# Altium schematic sheet TSAL_Control.SchDoc
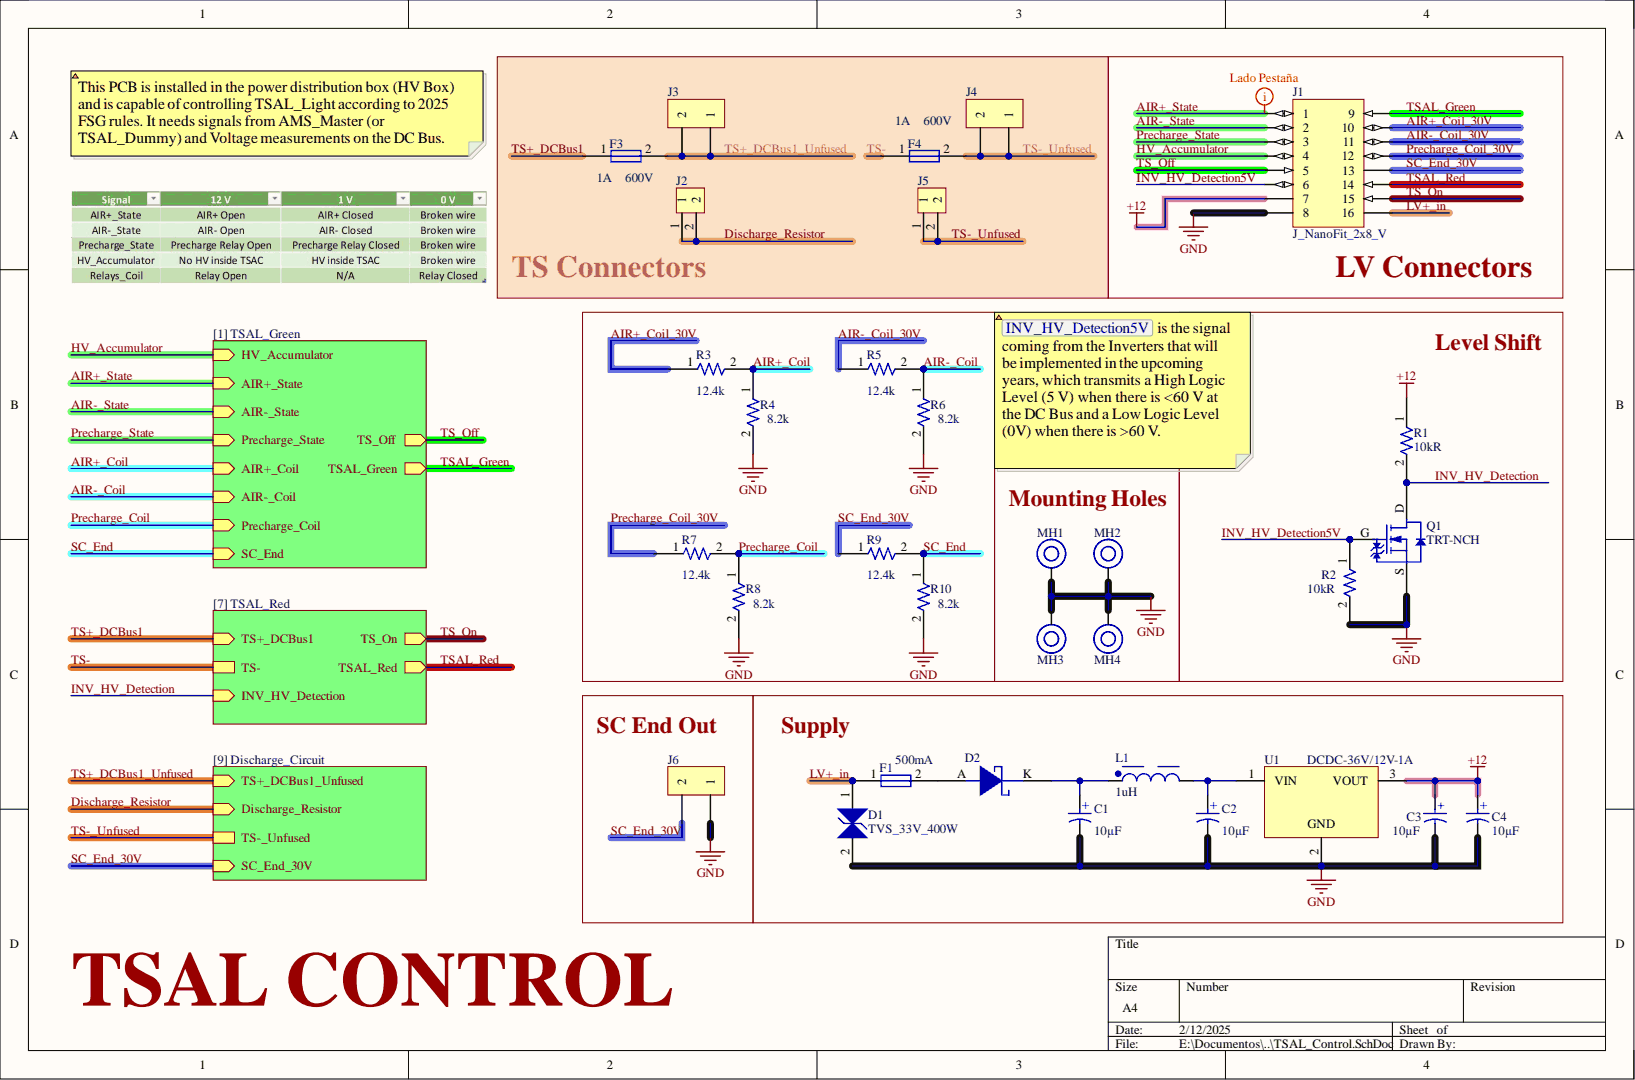
[diagram p0: TSAL_Control.SchDoc]

## Parts
C1  value 10µF  [865080540004-Footprint-1]  (hidden comment: C10u-35V-Electrolytic)
C2  value 10µF  [865080540004-Footprint-1]  (hidden comment: C10u-35V-Electrolytic)
C3  value 10µF  [865080540004-Footprint-1]  (hidden comment: C10u-35V-Electrolytic)
C4  value 10µF  [865080540004-Footprint-1]  (hidden comment: C10u-35V-Electrolytic)
D1  TVS_33V_400W  [WE-TVSP_DO-214AC_Bidirectional]
D2  Average Rectified Current: 500mA  [DSC-SOD123]  (hidden comment: DSC-0.5/30-SOD123)
F1  Current Rating: 500mA  [0805]  (hidden comment: MFU0805FF00500P500)
F3  Current Rating: 1A  Voltage Rating: 600V  [Fuse_485001]  (hidden comment: Fuse_1A_600V)
F4  Current Rating: 1A  Voltage Rating: 600V  [Fuse_485001]  (hidden comment: Fuse_1A_600V)
J1  J_NanoFit_2x8_V  [J_NanoFit_2x8_V]
J2  J_MicroFit_2x1  [J_MicroFit_2x1]  (value not drawn)
J3  J_NanoFit_1x2  [FP-105309-1102-MFG]  (value not drawn)
J4  J_NanoFit_1x2  [FP-105309-1102-MFG]  (value not drawn)
J5  J_MicroFit_2x1  [J_MicroFit_2x1]  (value not drawn)
J6  J_NanoFit_1x2  [FP-105309-1102-MFG]  (value not drawn)
L1  value 1uH  [WE-PD2_4532]  (hidden comment: 7447730)
MH1  Mounting_Hole_M3  [Mounting_Hole_M3]  (value not drawn)
MH2  Mounting_Hole_M3  [Mounting_Hole_M3]  (value not drawn)
MH3  Mounting_Hole_M3  [Mounting_Hole_M3]  (value not drawn)
MH4  Mounting_Hole_M3  [Mounting_Hole_M3]  (value not drawn)
Q1  TRT-NCH  [TRT-NCH-3/35-CPH]
R1  10kR  [R0805FTK]
R2  value 10kR  [R0805FTK]  (hidden comment: R10k-0805FTK)
R3  value 12.4k  [0805]  (hidden comment: R12K4-0805-0.125W)
R4  value 8.2k  [0805]  (hidden comment: R8.2K_0805_0.125W)
R5  value 12.4k  [0805]  (hidden comment: R12K4-0805-0.125W)
R6  value 8.2k  [0805]  (hidden comment: R8.2K_0805_0.125W)
R7  value 12.4k  [0805]  (hidden comment: R12K4-0805-0.125W)
R8  value 8.2k  [0805]  (hidden comment: R8.2K_0805_0.125W)
R9  value 12.4k  [0805]  (hidden comment: R12K4-0805-0.125W)
R10  value 8.2k  [0805]  (hidden comment: R8.2K_0805_0.125W)
U1  DCDC-36V/12V-1A  [WPME-FDSM_SIP-3_L11.6W8H10.4]

## Nets
+12: C3.1 C4.1 J1.7 R1.1 U1.3
GND: C1.2 C2.2 C3.2 C4.2 D1.2 J1.8 J6.1 MH1.1 MH2.1 MH3.1 MH4.1 Q1.S R2.2 R4.2 R6.2 R8.2 R10.2 U1.2
AIR+_Coil: R3.2 R4.1
AIR+_Coil_30V: J1.10 R3.1
AIR+_State: J1.1
AIR-_Coil: R5.2 R6.1
AIR-_Coil_30V: J1.11 R5.1
AIR-_State: J1.2
Discharge_Resistor: J2.1 J2.2
HV_Accumulator: J1.4
INV_HV_Detection: Q1.D R1.2
INV_HV_Detection5V: J1.6 Q1.G R2.1
LV+_in: D1.1 F1.1 J1.16
Precharge_Coil: R7.2 R8.1
Precharge_Coil_30V: J1.12 R7.1
Precharge_State: J1.3
SC_End: R9.2 R10.1
SC_End_30V: J1.13 J6.2 R9.1
TS+_DCBus1: F3.1
TS+_DCBus1_Unfused: F3.2 J3.1 J3.2
TS-: F4.1
TS-_Unfused: F4.2 J4.1 J4.2 J5.1 J5.2
TS_Off: J1.5
TS_On: J1.15
TSAL_Green: J1.9
TSAL_Red: J1.14
NetC1_1: C1.1 D2.K L1.1
NetC2_1: C2.1 L1.2 U1.1
NetD2_A: D2.A F1.2

## Library data of the parts
C1-C4 = CMP-001-00019-3: WCAP-ASLI Aluminum Electrolytic Capacitors; Ripple Current: 90mA; Tolerance: 20%; Voltage Rating: 35V; Case/Package: Radial; Component Kind: Standard; Max Operating Temperature: 105°C; Min Operating Temperature: -55°C; Mounting Technology: Surface Mount; Ripple Current (AC): 90mA; RoHS Compliant: Yes; Library Name: EMEA- E-Tech Racing- I'Escola d'Enginyeria de Barcelona Est
D1 = CMP-004-00003-1: Trans Voltage Suppressor Diode, 400W, Bidirectional, 1 Element, Silicon, DO-214AC; Component Kind: Standard; Max Operating Temperature: 150°C; Min Operating Temperature: -65°C; Pins: 2; RoHS Compliant: Yes; Library Name: EMEA- E-Tech Racing- I'Escola d'Enginyeria de Barcelona Est
D2 = CMP-00165-2: VR=30V IF=500mA 30pF P=410mW; .Creator: GP; Element Configuration: Single; Forward Voltage: 430mV; Max Forward Surge Current (Ifsm): 5.5A; Max Repetitive Reverse Voltage (Vrrm): 30V; Max Reverse Current: 130uA; Polarity: Standard; Category: Diode; Component Kind: Standard; ComponentLink1Description: Datasheet; ComponentLink2Description: Where buy it; ComponentLink3Description: https://datasheet.ciiva.com/30479/0900766b810e2512-30479401.pdf?src-supplier=RSComponents; ComponentLink3URL: https://datasheet.ciiva.com/30479/0900766b810e2512-30479401.pdf?src-supplier=RSComponents; ComponentLink4Description: https://datasheet.ciiva.com/34301/974753-34301826.pdf?src-supplier=Jameco; ComponentLink4URL: https://datasheet.ciiva.com/34301/974753-34301826.pdf?src-supplier=Jameco; ComponentLink5Description: https://datasheet.ciiva.com/910/mbr0530-910516.pdf?src-supplier=Digi-Key; ComponentLink5URL: https://datasheet.ciiva.com/910/mbr0530-910516.pdf?src-supplier=Digi-Key; ComponentLink6Description: https://datasheet.ciiva.com/25931/mbr0530-25931989.pdf?src-supplier=Avnet+Europe; ComponentLink6URL: https://datasheet.ciiva.com/25931/mbr0530-25931989.pdf?src-supplier=Avnet+Europe; ComponentLink7Description: https://datasheet.ciiva.com/8300/mbr0530-8300905.pdf?src-supplier=Avnet; ComponentLink7URL: https://datasheet.ciiva.com/8300/mbr0530-8300905.pdf?src-supplier=Avnet; ComponentLink8Description: https://datasheet.ciiva.com/17318/mbr0530-17318568.pdf?src-supplier=Future+Electronics; ComponentLink8URL: https://datasheet.ciiva.com/17318/mbr0530-17318568.pdf?src-supplier=Future+Electronics; ComponentLink9Description: https://datasheet.ciiva.com/15144/getdatasheetpartid-86760-15144598.pdf?src-supplier=Verical; ComponentLink9URL: https://datasheet.ciiva.com/15144/getdatasheetpartid-86760-15144598.pdf?src-supplier=Verical; ComponentLink10Description: https://datasheet.ciiva.com/910/mbr0530-910518.pdf?src-supplier=Digi-Key; ComponentLink10URL: https://datasheet.ciiva.com/910/mbr0530-910518.pdf?src-supplier=Digi-Key; Contact Plating: Tin,Matte; Current Rating: 500mA; Design Item ID: DSC-0.5/30-SOD123; Forward Current: 500mA; Height: 1.03mm; Lead Free: Lead Free; Length: 2.7mm; Max Reverse Leakage Current: 130uA; Max Reverse Voltage (DC): 30V; Max Surge Current: 5.5A; Mount: Surface Mount; Output Current: 500mA; Package Quantity: 1; Peak Non-Repetitive Surge Current: 5.5A; Pricing 1: 1=0,34400, 10=0,23900, 100=0,12200, 500=0,10000 (USD); Radiation Hardening: No; REACH SVHC: No SVHC; RoHS Compliant: Yes; Series: -; Source: Filter components.SchLib; Stock 1: 9; Voltage Rating (DC): 30V; Weight: 0.03g; Width: 1.6mm; Library Name: EMEA- E-Tech Racing- I'Escola d'Enginyeria de Barcelona Est
F1 = CMP-001-00020-3: Fuse, 24 Milliohms to 660 Milliohms (Cold) @ 0.1, 32 V, 0805, 15 C, 35 C; Value: 0.5A-50V; Case/Package: 0805; Component Kind: Standard; Max Operating Temperature: 125°C; Min Operating Temperature: 15°C; Mounting Technology: Surface Mount,PCB; Pins: 2; RoHS Compliant: Yes; Library Name: EMEA- E-Tech Racing- I'Escola d'Enginyeria de Barcelona Est
F3 F4 = CMP-00161-2: Surface Mount Fuses 600V 1A Fast Acting; Manufacturer 1: Littelfuse; Manufacturer Part Number 1: 0485001.DR; .Creator: DO; Approvals: UL,CSA; Body Material: Ceramic; DC Cold Resistance: 304.4mR; Fuse Type: Board Mount (Cartridge Style Excluded); Resistance: 0.264; Voltage Rating (AC): 250V; Case/Package: SMD/SMT; Category: Fuses; Component Kind: Standard; ComponentLink1Description: http://www.farnell.com/datasheets/1945989.pdf?src-supplier=Farnell; ComponentLink1URL: http://www.farnell.com/datasheets/1945989.pdf?src-supplier=Farnell; ComponentLink2Description: http://www.farnell.com/datasheets/2282568.pdf?src-supplier=Farnell; ComponentLink2URL: http://www.farnell.com/datasheets/2282568.pdf?src-supplier=Farnell; ComponentLink3Description: http://www.mouser.com/ds/2/240/Littelfuse485_datasheet_080512-201879.pdf?src-supplier=Mouser; ComponentLink3URL: http://www.mouser.com/ds/2/240/Littelfuse485_datasheet_080512-201879.pdf?src-supplier=Mouser; ComponentLink4Description: http://components.arrow.com/part/dataview/56707787S790276N3675?src-supplier=Arrow+Electronics; ComponentLink4URL: http://components.arrow.com/part/dataview/56707787S790276N3675?src-supplier=Arrow+Electronics; ComponentLink5Description: http://www.littelfuse.com/~/media/Files/Littelfuse/Technical%20Resources/Documents/Data%20Sheets/Littelfuse_Fuse_485_Datasheet.pdf?src-supplier=Element14; ComponentLink5URL: http://www.littelfuse.com/~/media/Files/Littelfuse/Technical%20Resources/Documents/Data%20Sheets/Littelfuse_Fuse_485_Datasheet.pdf?src-supplier=Element14; ComponentLink6Description: http://www.littelfuse.com/~/media/electronics/datasheets/fuses/littelfuse_fuse_485_datasheet.pdf.pdf?src-supplier=Digi-Key; ComponentLink6URL: http://www.littelfuse.com/~/media/electronics/datasheets/fuses/littelfuse_fuse_485_datasheet.pdf.pdf?src-supplier=Digi-Key; Depth: 4.5mm; Design Item ID: Fuse_1A_600V; Fuse Current: 1A; Height: 0.177inch; Housing Material: Ceramic; Lead Free: Lead Free; Length: 12.1mm; Max Operating Temperature: 125°C; Min Operating Temperature: -55°C; Mount: Surface Mount; Package Quantity: 1; Packaging: Tape and Reel; Pricing 1: 1=2,82900, 5=2,81400, 10=2,75200, 50=2,59800, 100=2,40300, 250=2,37900, 500=2,30400, 1500=2,24900, 3000=2,11600 (USD); REACH SVHC: No SVHC; RoHS: Yes; RoHS Compliant: Yes; Series: 485; Source: Filter components.SchLib; Stock 1: 4123; Termination: SMD/SMT; Voltage Rating (DC): 600V; Width: 0.177inch; Library Name: EMEA- E-Tech Racing- I'Escola d'Enginyeria de Barcelona Est
J1 = CMP-00020-3: Nano-Fit Vertical Header Through Hole 2.50mm Pitch Dual Row 16 Circuits with Kinked Pins Tin (Sn) Plating Black; Manufacturer 1: Molex; Manufacturer Part Number 1: 105310-1116; .Creator: AC; Connector Type: Header,Male Pin; Number of Positions: 16; Category: Headers and Wire Housings; Component Kind: Standard; ComponentLink1Description: https://www.molex.com/pdm_docs/sd/1053101210_sd.pdf?src-supplier=Digi-Key; ComponentLink1URL: https://www.molex.com/pdm_docs/sd/1053101210_sd.pdf?src-supplier=Digi-Key; ComponentLink2Description: Molex; ComponentLink2URL: https://www.molex.com/molex/products/part-detail/pcb_headers/1053101116; Contact Material: Brass; Contact Plating: Tin; Contact Shape: Square; Design Item ID: J_NanoFit_2x8_V; Fastening Type: Latch; Insulation Color: Black; Insulation Material: Polymer; Max Operating Temperature: 105°C; Min Operating Temperature: -40°C; Mount: Through Hole; Number of Rows: 2; Packaging: Tray; Pricing 1: 1=3,21000, 10=2,82400, 84=2,24619, 1008=1,86110, 4956=1,66858 (USD); RoHS: No; Source: Electromechanical.SchLib; Stock 1: 84; Termination: Kinked Pin; Library Name: EMEA- E-Tech Racing- I'Escola d'Enginyeria de Barcelona Est
J2 J5 = CMP-00012-1: Header 1 Row Vertical Polarizing Peg 2 Pin SMT/Glow Wire Compatible Tin Plate; Manufacturer 1: Molex; Manufacturer Part Number 1: 43650-0215; Category: Headers and Wire Housings; Component Kind: Standard; ComponentLink1Description: https://datasheet.ciiva.com/19462/884109-19462034.pdf?src-supplier=Jameco; ComponentLink1URL: https://datasheet.ciiva.com/19462/884109-19462034.pdf?src-supplier=Jameco; ComponentLink2Description: https://datasheet.ciiva.com/5157/0436500215-pcb-headers-148687-5157608.pdf?src-supplier=Mouser; ComponentLink2URL: https://datasheet.ciiva.com/5157/0436500215-pcb-headers-148687-5157608.pdf?src-supplier=Mouser; ComponentLink3Description: https://datasheet.ciiva.com/16085/0436500215-pcb-headers-16085668.pdf?src-supplier=Onlinecomponents.com; ComponentLink3URL: https://datasheet.ciiva.com/16085/0436500215-pcb-headers-16085668.pdf?src-supplier=Onlinecomponents.com; ComponentLink4Description: https://datasheet.ciiva.com/11748/0900766b80307ce2-11748388.pdf?src-supplier=RSComponents; ComponentLink4URL: https://datasheet.ciiva.com/11748/0900766b80307ce2-11748388.pdf?src-supplier=RSComponents; ComponentLink5Description: https://datasheet.ciiva.com/9432/0436500215-pcb-headers-9432850.pdf?src-supplier=Avnet; ComponentLink5URL: https://datasheet.ciiva.com/9432/0436500215-pcb-headers-9432850.pdf?src-supplier=Avnet; ComponentLink6Description: https://datasheet.ciiva.com/7920/1889390-7920892.pdf?src-supplier=Element14; ComponentLink6URL: https://datasheet.ciiva.com/7920/1889390-7920892.pdf?src-supplier=Element14; Design Item ID: J_MicroFit_2x1; Pricing 1: 1=0,87800, 10=0,74400, 80=0,63070, 3264=0,47000, 6528=0,45100, 13056=0,41760 (USD); RoHS: Yes; Source: Electromechanical.SchLib; Stock 1: 11086; Library Name: EMEA- E-Tech Racing- I'Escola d'Enginyeria de Barcelona Est
J3 J4 J6 = CMP-002-00001-2: Conn HDR 2 POS 2.5mm Solder ST Thru-Hole 2 Terminal 1 Port Nano-Fit Tray; Component Kind: Standard; Library Name: EMEA- E-Tech Racing- I'Escola d'Enginyeria de Barcelona Est
L1 = CMP-006-00005-1: General Purpose Inductor, 1uH, 20%, 1 Element, Nickel-zinc Ferrite-Core, SMD, 1816; Max Current Rating: 4A; Self Resonant Frequency: 110MHz; Component Kind: Standard; Max Operating Temperature: 125°C; Min Operating Temperature: -40°C; Pins: 2; RoHS Compliant: Yes; Tolerance: 20%; Library Name: EMEA- E-Tech Racing- I'Escola d'Enginyeria de Barcelona Est
MH1-MH4 = CMP-00040-2: Component Kind: Standard; Design Item ID: Mounting_Hole_M3; Source: Electromechanical.SchLib; Library Name: EMEA- E-Tech Racing- I'Escola d'Enginyeria de Barcelona Est
Q1 = CMP-00142-1: Automotive N-channel 40 V (d-s) 175C Mosfet SOT-23; Manufacturer 1: Vishay; Manufacturer Part Number 1: SQ2318BES-T1_GE3; .Creator: DO; Vds: 40V; Component Kind: Standard; ComponentLink1Description: Where to buy; ComponentLink1URL: https://www.mouser.es/ProductDetail/Vishay-Siliconix/SQ2318BES-T1_GE3?qs=sPbYRqrBIVmyZcxPsDt0vA%3D%3D; ComponentLink2Description: Datasheet; ComponentLink2URL: https://www.mouser.es/datasheet/2/427/sq2318bes-1868475.pdf; Design Item ID: TRT-NCH-8/40-SQ; Pricing 1: 1=0,71500, 5=0,71500, 10=0,64400, 25=0,59000, 50=0,52500, 100=0,47100, 250=0,40800 (USD); RoHS: No; Source: Semiconductor.SchLib; Library Name: EMEA- E-Tech Racing- I'Escola d'Enginyeria de Barcelona Est
R1 = CMP-00085-4: BOURNS         CR0805-JW-103ELF            Surface Mount Chip Resistor, Thick Film, CR Series, 10 kohm, 125 mW,  5%, 150 V; .Creator: DR; Max Power Dissipation: 125mW; Temperature Coefficient: 200ppm/°C; Value: 10k; Voltage Rating: 150V; Voltage Rating (DC): 100V; Case Code (Metric): 2012; Case/Package: 0805; Category: Chip SMD Resistors; Component Kind: Standard; ComponentLink1Description: https://datasheet.ciiva.com/11821/0900766b80fcdb72-11821135.pdf?src-supplier=RSComponents; ComponentLink1URL: https://datasheet.ciiva.com/11821/0900766b80fcdb72-11821135.pdf?src-supplier=RSComponents; ComponentLink2Description: https://datasheet.ciiva.com/9122/chpreztr-9122374.pdf?src-supplier=Avnet; ComponentLink2URL: https://datasheet.ciiva.com/9122/chpreztr-9122374.pdf?src-supplier=Avnet; ComponentLink3Description: https://datasheet.ciiva.com/22693/1902866-22693254.pdf?src-supplier=Element14; ComponentLink3URL: https://datasheet.ciiva.com/22693/1902866-22693254.pdf?src-supplier=Element14; ComponentLink4Description: https://datasheet.ciiva.com/7986/691949-7986187.pdf?src-supplier=Element14; ComponentLink4URL: https://datasheet.ciiva.com/7986/691949-7986187.pdf?src-supplier=Element14; ComponentLink5Description: https://datasheet.ciiva.com/30065/159chpreztr-30065976.pdf?src-supplier=Onlinecomponents.com; ComponentLink5URL: https://datasheet.ciiva.com/30065/159chpreztr-30065976.pdf?src-supplier=Onlinecomponents.com; ComponentLink6Description: https://datasheet.ciiva.com/16783/70154685-16783482.pdf?src-supplier=Allied+Electronics; ComponentLink6URL: https://datasheet.ciiva.com/16783/70154685-16783482.pdf?src-supplier=Allied+Electronics; ComponentLink7Description: https://datasheet.ciiva.com/5548/chpreztr-5548491.pdf?src-supplier=Mouser; ComponentLink7URL: https://datasheet.ciiva.com/5548/chpreztr-5548491.pdf?src-supplier=Mouser; ComponentLink8Description: https://datasheet.ciiva.com/6559/chpreztr-6559900.pdf?src-supplier=Digi-Key; ComponentLink8URL: https://datasheet.ciiva.com/6559/chpreztr-6559900.pdf?src-supplier=Digi-Key; ComponentLink9Description: https://datasheet.ciiva.com/6559/chpreztr-6559901.pdf?src-supplier=Digi-Key; ComponentLink9URL: https://datasheet.ciiva.com/6559/chpreztr-6559901.pdf?src-supplier=Digi-Key; ComponentLink10Description: https://datasheet.ciiva.com/7985/1856384-7985869.pdf?src-supplier=Element14; ComponentLink10URL: https://datasheet.ciiva.com/7985/1856384-7985869.pdf?src-supplier=Element14; ComponentLink11Description: https://datasheet.ciiva.com/48692/001055517-da-01-en-smd-wid-cr0805-jw-103elf-10k-ohm-1-8w-48692118.pdf?src-supplier=Conrad; ComponentLink11URL: https://datasheet.ciiva.com/48692/001055517-da-01-en-smd-wid-cr0805-jw-103elf-10k-ohm-1-8w-48692118.pdf?src-supplier=Conrad; Construction: Rectangular; Contact Plating: Tin; Depth: 1.25mm; Design Item ID: R10k-0805FTK; Features: Moisture Resistant; Height: 0.5mm; Length: 2mm; Manufacturer 1: Bourns; Manufacturer Part Number 1: CR0805-JW-103ELF; Max Operating Temperature: 155°C; Military Standard: Not; Min Operating Temperature: -55°C; Mount: Surface Mount; Number of Terminations: 2; Package Quantity: 1; Packaging: Tape and Reel; Pins: 2; Power Rating: 125mW; Pricing 1: 1=0,05790, 10=0,01540, 25=0,01340, 100=0,00340 (USD); Radiation Hardening: No; REACH SVHC: No SVHC; Resistance Tolerance: 5%; Resistor Type: Thick Film; RoHS: Yes; RoHS Compliant: Yes; Series: CR0805; Source: Passives.SchLib; Stock 1: 57566; Technology: THICK FILM; Termination: SMD/SMT; Thickness: 508um; Tolerance: 5%; Width: 1.25mm; Library Name: EMEA- E-Tech Racing- I'Escola d'Enginyeria de Barcelona Est
R2 = CMP-00085-4: BOURNS         CR0805-JW-103ELF            Surface Mount Chip Resistor, Thick Film, CR Series, 10 kohm, 125 mW,  5%, 150 V; .Creator: DR; Max Power Dissipation: 125mW; Temperature Coefficient: 200ppm/°C; Voltage Rating: 150V; Voltage Rating (DC): 100V; Case Code (Metric): 2012; Case/Package: 0805; Category: Chip SMD Resistors; Component Kind: Standard; ComponentLink1Description: https://datasheet.ciiva.com/11821/0900766b80fcdb72-11821135.pdf?src-supplier=RSComponents; ComponentLink1URL: https://datasheet.ciiva.com/11821/0900766b80fcdb72-11821135.pdf?src-supplier=RSComponents; ComponentLink2Description: https://datasheet.ciiva.com/9122/chpreztr-9122374.pdf?src-supplier=Avnet; ComponentLink2URL: https://datasheet.ciiva.com/9122/chpreztr-9122374.pdf?src-supplier=Avnet; ComponentLink3Description: https://datasheet.ciiva.com/22693/1902866-22693254.pdf?src-supplier=Element14; ComponentLink3URL: https://datasheet.ciiva.com/22693/1902866-22693254.pdf?src-supplier=Element14; ComponentLink4Description: https://datasheet.ciiva.com/7986/691949-7986187.pdf?src-supplier=Element14; ComponentLink4URL: https://datasheet.ciiva.com/7986/691949-7986187.pdf?src-supplier=Element14; ComponentLink5Description: https://datasheet.ciiva.com/30065/159chpreztr-30065976.pdf?src-supplier=Onlinecomponents.com; ComponentLink5URL: https://datasheet.ciiva.com/30065/159chpreztr-30065976.pdf?src-supplier=Onlinecomponents.com; ComponentLink6Description: https://datasheet.ciiva.com/16783/70154685-16783482.pdf?src-supplier=Allied+Electronics; ComponentLink6URL: https://datasheet.ciiva.com/16783/70154685-16783482.pdf?src-supplier=Allied+Electronics; ComponentLink7Description: https://datasheet.ciiva.com/5548/chpreztr-5548491.pdf?src-supplier=Mouser; ComponentLink7URL: https://datasheet.ciiva.com/5548/chpreztr-5548491.pdf?src-supplier=Mouser; ComponentLink8Description: https://datasheet.ciiva.com/6559/chpreztr-6559900.pdf?src-supplier=Digi-Key; ComponentLink8URL: https://datasheet.ciiva.com/6559/chpreztr-6559900.pdf?src-supplier=Digi-Key; ComponentLink9Description: https://datasheet.ciiva.com/6559/chpreztr-6559901.pdf?src-supplier=Digi-Key; ComponentLink9URL: https://datasheet.ciiva.com/6559/chpreztr-6559901.pdf?src-supplier=Digi-Key; ComponentLink10Description: https://datasheet.ciiva.com/7985/1856384-7985869.pdf?src-supplier=Element14; ComponentLink10URL: https://datasheet.ciiva.com/7985/1856384-7985869.pdf?src-supplier=Element14; ComponentLink11Description: https://datasheet.ciiva.com/48692/001055517-da-01-en-smd-wid-cr0805-jw-103elf-10k-ohm-1-8w-48692118.pdf?src-supplier=Conrad; ComponentLink11URL: https://datasheet.ciiva.com/48692/001055517-da-01-en-smd-wid-cr0805-jw-103elf-10k-ohm-1-8w-48692118.pdf?src-supplier=Conrad; Construction: Rectangular; Contact Plating: Tin; Depth: 1.25mm; Design Item ID: R10k-0805FTK; Features: Moisture Resistant; Height: 0.5mm; Length: 2mm; Manufacturer 1: Bourns; Manufacturer Part Number 1: CR0805-JW-103ELF; Max Operating Temperature: 155°C; Military Standard: Not; Min Operating Temperature: -55°C; Mount: Surface Mount; Number of Terminations: 2; Package Quantity: 1; Packaging: Tape and Reel; Pins: 2; Power Rating: 125mW; Pricing 1: 1=0,05790, 10=0,01540, 25=0,01340, 100=0,00340 (USD); Radiation Hardening: No; REACH SVHC: No SVHC; Resistance Tolerance: 5%; Resistor Type: Thick Film; RoHS: Yes; RoHS Compliant: Yes; Series: CR0805; Source: Passives.SchLib; Stock 1: 57566; Technology: THICK FILM; Termination: SMD/SMT; Thickness: 508um; Tolerance: 5%; Width: 1.25mm; Library Name: EMEA- E-Tech Racing- I'Escola d'Enginyeria de Barcelona Est
R3 R5 R7 R9 = CMP-009-00046-1: Fixed Resistor, Metal Glaze/thick Film, 0.125W, 12400ohm, 150V, 1% +/-Tol, 100ppm/Cel, Surface Mount, 0805; Power: 125mW; Case/Package: 0805; Component Kind: Standard; Max Operating Temperature: 155°C; Min Operating Temperature: -55°C; Mounting Technology: Surface Mount; Pins: 2; RoHS Compliant: Yes; Tolerance: 1%; Library Name: EMEA- E-Tech Racing- I'Escola d'Enginyeria de Barcelona Est
R4 R6 R8 R10 = CMP-009-00044-2: Fixed Resistor, Metal Glaze/thick Film, 0.125W, 8200ohm, 150V, 1% +/-Tol, 100ppm/Cel, Surface Mount, 0805; Power: 125mW; Case/Package: 0805; Component Kind: Standard; Max Operating Temperature: 155°C; Min Operating Temperature: -55°C; Mounting Technology: Surface Mount; Pins: 2; RoHS Compliant: Yes; Tolerance: 1%; Library Name: EMEA- E-Tech Racing- I'Escola d'Enginyeria de Barcelona Est
U1 = CMP-019-00006-1: Switching Regulator 36V In / 12 V Out, 1A. 173011235; Component Kind: Standard; RoHS Compliant: Yes; Library Name: EMEA- E-Tech Racing- I'Escola d'Enginyeria de Barcelona Est
